# Altium schematic sheet [04] - RP2040.SchDoc
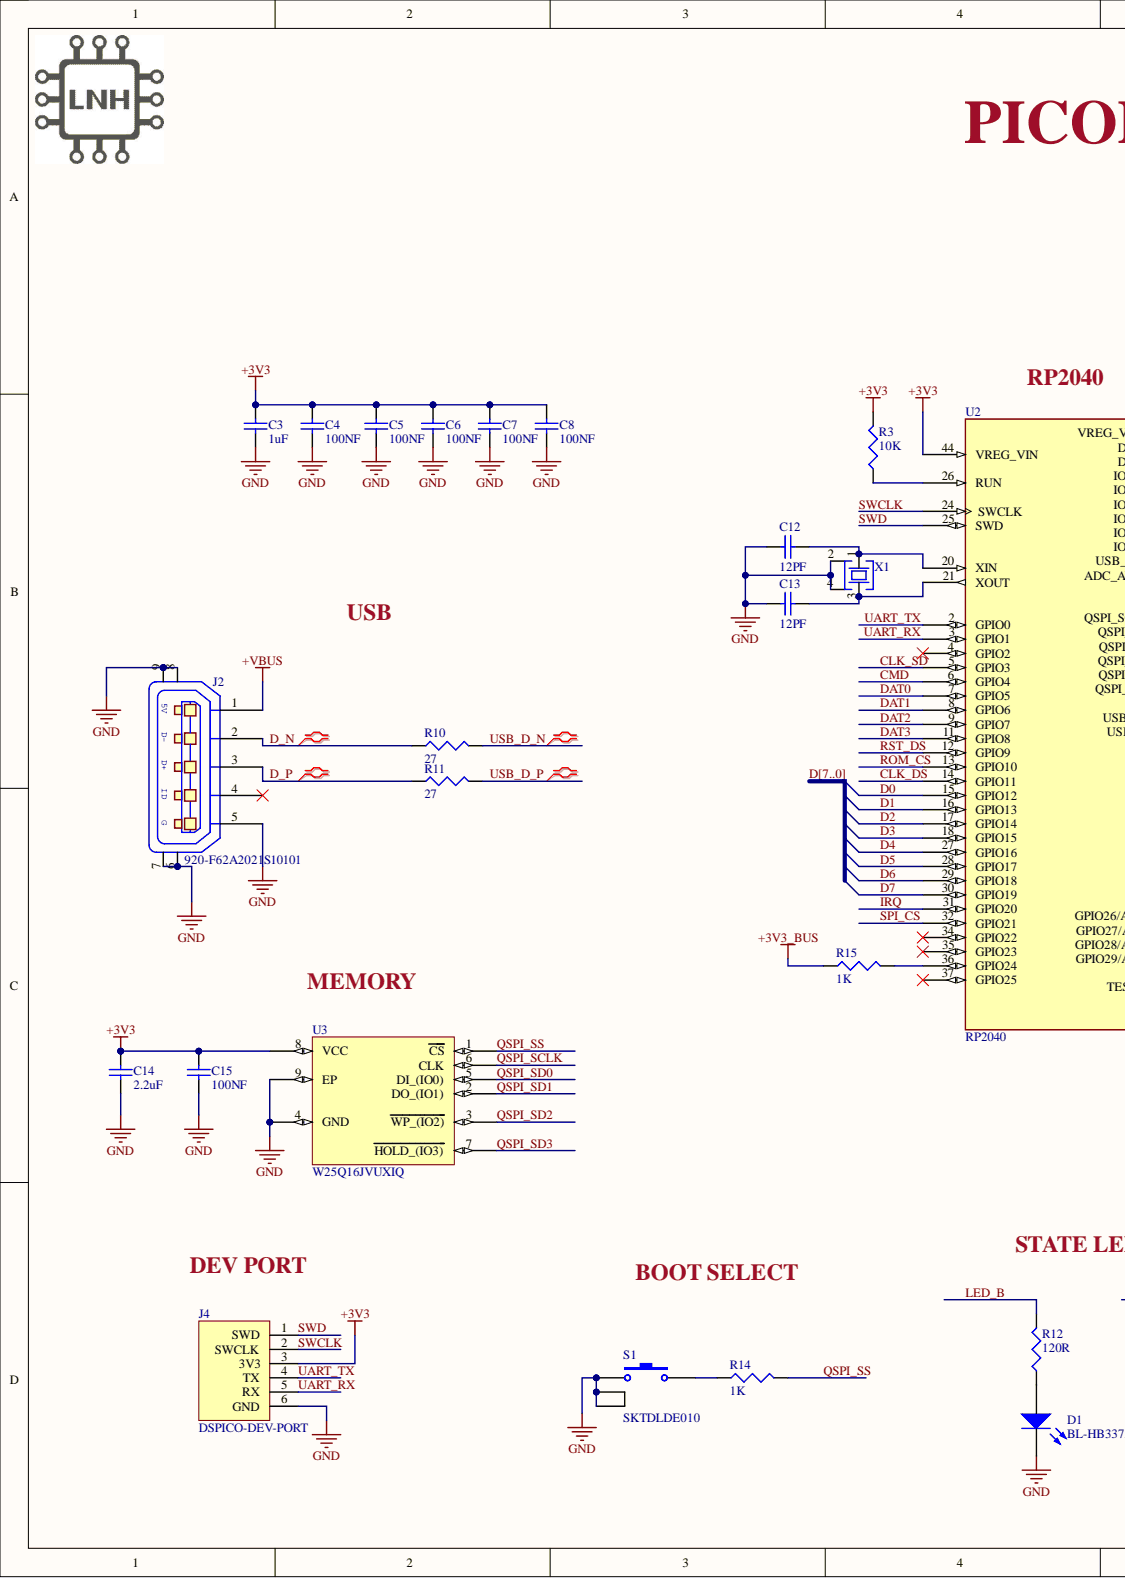
[diagram p0: [04] - RP2040.SchDoc, left]
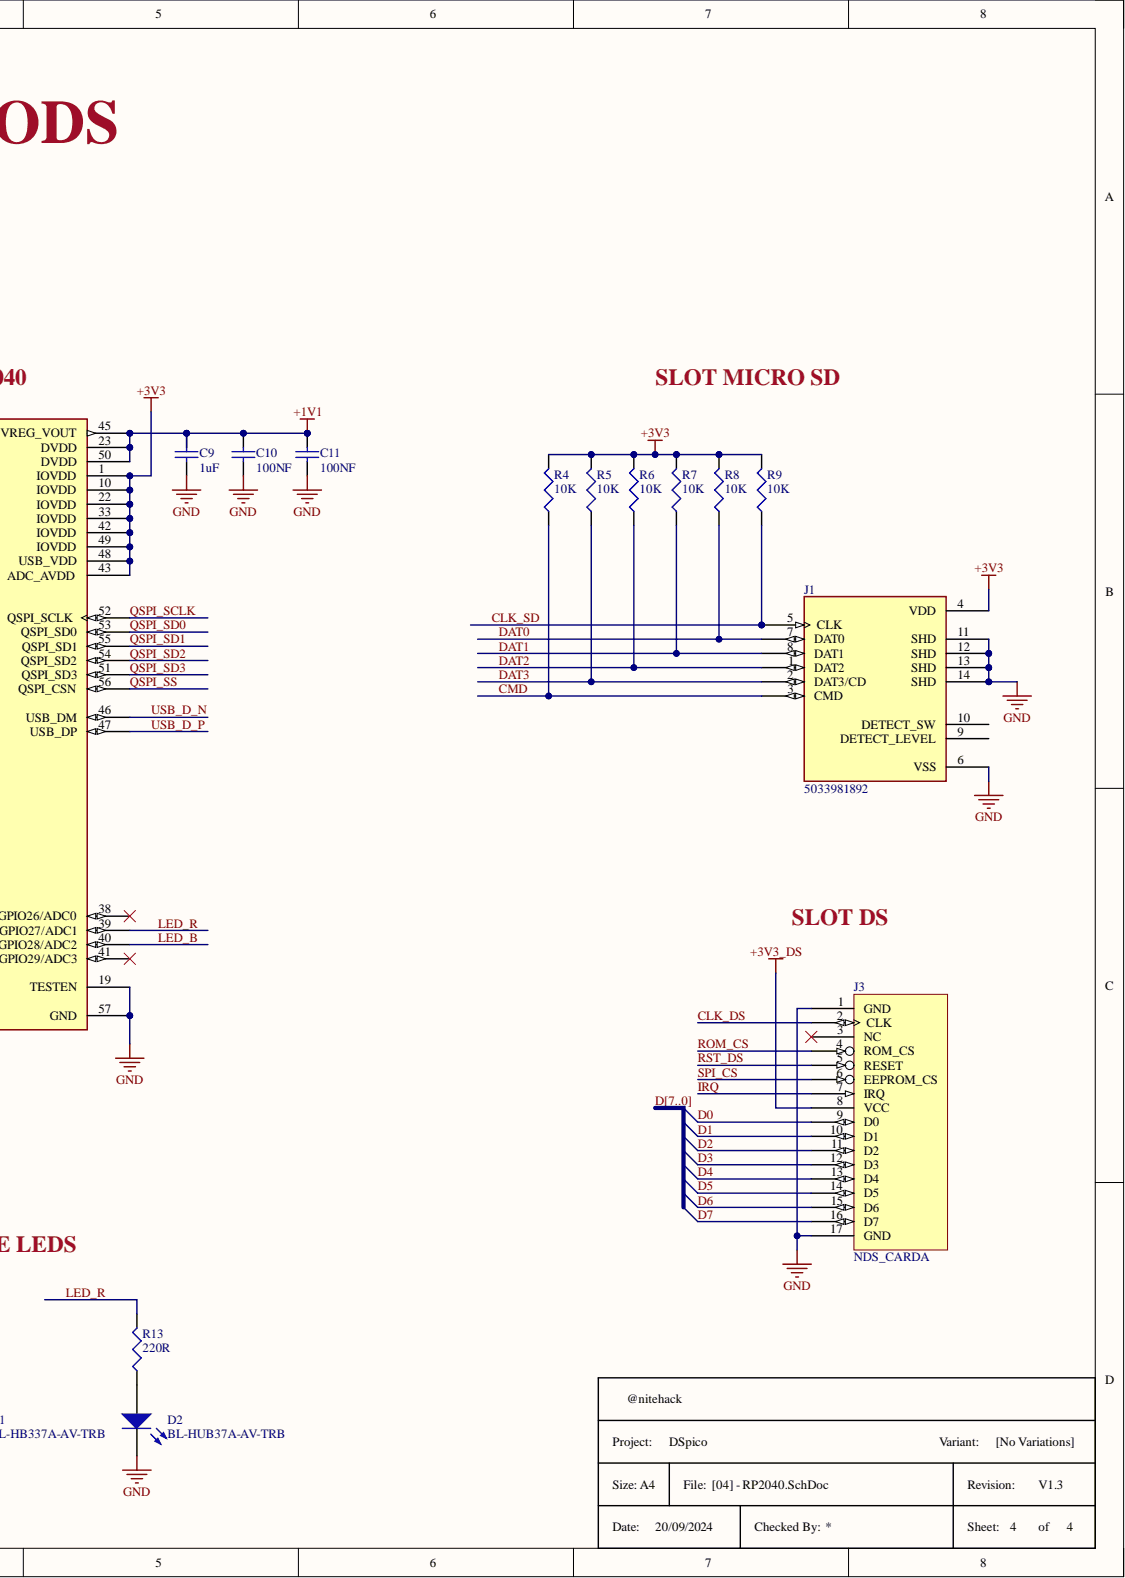
[diagram p1: [04] - RP2040.SchDoc, right]

## Parts
C3  1uF  [C0402]
C4  100NF  [C0402]
C5  100NF  [C0402]
C6  100NF  [C0402]
C7  100NF  [C0402]
C8  100NF  [C0402]
C9  1uF  [C0402]
C10  100NF  [C0402]
C11  100NF  [C0402]
C12  12PF  [C0402]
C13  12PF  [C0402]
C14  2.2uF  [C0402]
C15  100NF  [C0402]
D1  BL-HB337A-AV-TRB  [LED0402-RD]
D2  BL-HUB37A-AV-TRB  [LED0402-RD]
J1  5033981892  [503398-1892_MOL]
J2  920-F62A2021S10101  [MICRO-USB_MICRO-USB-31]
J3  NDS_CARDA  [NDS_CARD]  (no BOM)
J4  DSPICO-DEV-PORT  [EP_DEV_PORT]  (no BOM)
R3  10K  [R0402]
R4  10K  [R0402]
R5  10K  [R0402]
R6  10K  [R0402]
R7  10K  [R0402]
R8  10K  [R0402]
R9  10K  [R0402]
R10  value 27  [R0402]  (hidden comment: RC0402FR-0727RL)
R11  value 27  [R0402]  (hidden comment: RC0402FR-0727RL)
R12  120R  [R0402]
R13  220R  [R0402]
R14  1K  [R0402]
R15  1K  [R0402]
S1  SKTDLDE010  [KEY-SMD_SKTDLDE010]
U2  RP2040  [QFN40P700X700X90-57N]
U3  W25Q16JVUXIQ  [SON50P300X200X60-9N]
X1  XYDBPCNANF-12MHZ  [OSC-SMD_4P-L2.5-W2.0-BL]  (value not drawn)

## Nets
+1V1: C9.2 C10.2 C11.2 U2.23(DVDD) U2.45(VREG_VOUT) U2.50(DVDD)
+3V3: C3.2 C4.2 C5.2 C6.2 C7.2 C8.2 C14.2 C15.2 J1.4(VDD) J4.3(3V3) R3.2 R4.2 R5.2 R6.2 R7.2 R8.2 R9.2 U2.1(IOVDD) U2.10(IOVDD) U2.22(IOVDD) U2.33(IOVDD) U2.42(IOVDD) U2.43(ADC_AVDD) U2.44(VREG_VIN) U2.48(USB_VDD) U2.49(IOVDD) U3.8(VCC)
+3V3_BUS: R15.1
+3V3_DS: J3.8(VCC)
+VBUS: J2.1
GND: C3.1 C4.1 C5.1 C6.1 C7.1 C8.1 C9.1 C10.1 C11.1 C12.1 C13.1 C14.1 C15.1 D1.1 D2.1 J1.6(VSS) J1.11(SHD) J1.12(SHD) J1.13(SHD) J1.14(SHD) J2.5 J2.6 J2.7 J2.8 J2.9 J3.1(GND) J3.17(GND) J4.6(GND) S1.1 S1.3 S1.4 U2.19(TESTEN) U2.57(GND) U3.4(GND) U3.9(EP) X1.2 X1.4
CLK_DS: J3.2(CLK) U2.14(GPIO11)
CLK_SD: J1.5(CLK) R9.1 U2.5(GPIO3)
CMD: J1.3(CMD) R4.1 U2.6(GPIO4)
D0: J3.9(D0) U2.15(GPIO12)  [also labelled D]
D1: J3.10(D1) U2.16(GPIO13)  [also labelled D]
D2: J3.11(D2) U2.17(GPIO14)  [also labelled D]
D3: J3.12(D3) U2.18(GPIO15)  [also labelled D]
D4: J3.13(D4) U2.27(GPIO16)  [also labelled D]
D5: J3.14(D5) U2.28(GPIO17)  [also labelled D]
D6: J3.15(D6) U2.29(GPIO18)  [also labelled D]
D7: J3.16(D7) U2.30(GPIO19)  [also labelled D]
D_N: J2.2 R10.1
D_P: J2.3 R11.1
DAT0: J1.7(DAT0) R8.1 U2.7(GPIO5)
DAT1: J1.8(DAT1) R7.1 U2.8(GPIO6)
DAT2: J1.1(DAT2) R6.1 U2.9(GPIO7)
DAT3: J1.2(DAT3/CD) R5.1 U2.11(GPIO8)
IRQ: J3.7(IRQ) U2.31(GPIO20)
LED_B: R12.2 U2.40(GPIO28/ADC2)
LED_R: R13.2 U2.39(GPIO27/ADC1)
QSPI_SCLK: U2.52(QSPI_SCLK) U3.6(CLK)
QSPI_SD0: U2.53(QSPI_SD0) U3.5(DI_(IO0))
QSPI_SD1: U2.55(QSPI_SD1) U3.2(DO_(IO1))
QSPI_SD2: U2.54(QSPI_SD2) U3.3(WP_(IO2))
QSPI_SD3: U2.51(QSPI_SD3) U3.7(HOLD_(IO3))
QSPI_SS: R14.2 U2.56(QSPI_CSN) U3.1(CS)
ROM_CS: J3.4(ROM_CS) U2.13(GPIO10)
RST_DS: J3.5(RESET) U2.12(GPIO9)
SPI_CS: J3.6(EEPROM_CS) U2.32(GPIO21)
SWCLK: J4.2(SWCLK) U2.24(SWCLK)
SWD: J4.1(SWD) U2.25(SWD)
UART_RX: J4.5(RX) U2.3(GPIO1)
UART_TX: J4.4(TX) U2.2(GPIO0)
USB_D_N: R10.2 U2.46(USB_DM)
USB_D_P: R11.2 U2.47(USB_DP)
NetC12_2: C12.2 U2.20(XIN) X1.1
NetC13_2: C13.2 U2.21(XOUT) X1.3
NetD1_2: D1.2 R12.1
NetD2_2: D2.2 R13.1
NetR3_1: R3.1 U2.26(RUN)
NetR14_1: R14.1 S1.2
NetR15_2: R15.2 U2.36(GPIO24)

Not connected: J1 9(DETECT_LEVEL) 10(DETECT_SW); J2 4; J3 3(NC); U2 4(GPIO2) 34(GPIO22) 35(GPIO23) 37(GPIO25) 38(GPIO26/ADC0) 41(GPIO29/ADC3)

## Library data of the parts
C3 C9 = C1005X5R1E105K050BC: TDK - C1005X5R1E105K050BC - COND, CERÁ MULT, X5R, 1UF, 25V, 0402; Dielectric Material: Ceramic; Tolerance: 10%; Capacitance: 1uF; Case Code (Imperial): 0402; Case Code (Metric): 1005; Case/Package: 0402; Component Kind: Standard; ComponentLink1Description: http://www.farnell.com/datasheets/1796654.pdf; ComponentLink1URL: http://www.farnell.com/datasheets/1796654.pdf; Composition: Ceramic; Depth: 0.5mm; Dielectric: X5R; Height: 0.5mm; Lead Free: Lead Free; Length: 1mm; Library Name: SCHLIB.SchLib; Manufacturer: TDK; Manufacturer Part Number: C1005X5R1E105K050BC; Max Operating Temperature: 85°C; Min Operating Temperature: -55°C; Mount: Surface Mount; Package Quantity: 1; Packaging: Tape and Reel; Pricing: 10=0,0948, 100=0,0638, 500=0,0468, 2500=0,0336, 5000=0,028, 10000=0,021, 50000=0,0175, 100000=0,0153 (EUR); Radiation Hardening: No; REACH SVHC: No SVHC; RoHS: YES; Stock: 131328; Storage Location: C1-F2; Supplier 1: Farnell; Supplier 2: RSComponents; Supplier 3: Mouser; Supplier 4: Digi-Key; Supplier Part Number 1: 2346884; Supplier Part Number 2: 1110310; Supplier Part Number 3: 810-C1005X5R1E105K; Supplier Part Number 4: 445-9066-1-ND; Termination: SMD/SMT; Thickness: 0.022inch; Voltage Rating: 25V; Voltage Rating (DC): 25V; Weight: 2.3E-05oz; Width: 0.02inch
C4-C8 C10 C11 C15 = GRM155R71C104KA88D: 0402 0.1 uF 16V ±10 % Tolerance X7R SMT Multilayer Ceramic Capacitor; Manufacturer: Murata; Insulation Resistance: 10GR; Tolerance: 10%; Capacitance: 100nF; Case Code (Imperial): 0402; Case Code (Metric): 1005; Case/Package: 0402; Category: Ceramic Capacitors; Component Kind: Standard; ComponentLink1Description: https://datasheet.ciiva.com/129/grm155r71c104ka88-01-129739.pdf?src-supplier=Digi-Key; ComponentLink1URL: https://datasheet.ciiva.com/129/grm155r71c104ka88-01-129739.pdf?src-supplier=Digi-Key; ComponentLink2Description: https://datasheet.ciiva.com/129/grm155r71c104ka88-01-129740.pdf?src-supplier=Digi-Key; ComponentLink2URL: https://datasheet.ciiva.com/129/grm155r71c104ka88-01-129740.pdf?src-supplier=Digi-Key; ComponentLink3Description: https://datasheet.ciiva.com/18102/getdatasheetpartid-85307-18102905.pdf?src-supplier=Verical; ComponentLink3URL: https://datasheet.ciiva.com/18102/getdatasheetpartid-85307-18102905.pdf?src-supplier=Verical; ComponentLink4Description: https://datasheet.ciiva.com/29199/454098-da-01-en-vs-kondensator-0402-29199799.pdf?src-supplier=Conrad; ComponentLink4URL: https://datasheet.ciiva.com/29199/454098-da-01-en-vs-kondensator-0402-29199799.pdf?src-supplier=Conrad; ComponentLink5Description: https://datasheet.ciiva.com/7937/4360-7937615.pdf?src-supplier=Element14; ComponentLink5URL: https://datasheet.ciiva.com/7937/4360-7937615.pdf?src-supplier=Element14; ComponentLink6Description: https://datasheet.ciiva.com/14116/grmseries-highdielectrictype-eng-14116878.pdf?src-supplier=Distrelec; ComponentLink6URL: https://datasheet.ciiva.com/14116/grmseries-highdielectrictype-eng-14116878.pdf?src-supplier=Distrelec; ComponentLink7Description: https://datasheet.ciiva.com/14103/grmseries-highdielectrictype-eng-14103750.pdf?src-supplier=Distrelec; ComponentLink7URL: https://datasheet.ciiva.com/14103/grmseries-highdielectrictype-eng-14103750.pdf?src-supplier=Distrelec; ComponentLink8Description: https://datasheet.ciiva.com/28534/4360-28534127.pdf?src-supplier=Element14; ComponentLink8URL: https://datasheet.ciiva.com/28534/4360-28534127.pdf?src-supplier=Element14; ComponentLink9Description: https://datasheet.ciiva.com/28534/4360-28534133.pdf?src-supplier=Element14; ComponentLink9URL: https://datasheet.ciiva.com/28534/4360-28534133.pdf?src-supplier=Element14; ComponentLink10Description: https://datasheet.ciiva.com/16199/product-16199000.pdf?src-supplier=Future+Electronics; ComponentLink10URL: https://datasheet.ciiva.com/16199/product-16199000.pdf?src-supplier=Future+Electronics; Depth: 0.5mm; Dielectric: X7R; Dielectric Material: Ceramic; Height: 0.5mm; Lead Free: Lead Free; Length: 1mm; Library Name: SCHLIB.SchLib; Manufacturer Part Number: GRM155R71C104KA88D; Material: Ceramic; Max Operating Temperature: 125°C; Min Operating Temperature: -55°C; Mount: Surface Mount; Number of Pins: 2; Package Quantity: 1; Packaging: Tape and Reel; Pricing 1: 1=0,10000, 10=0,02200, 50=0,01180, 100=0,00960, 500=0,00688, 1000=0,00541, 2500=0,00492, 5000=0,00452 (USD); Radiation Hardening: No; REACH SVHC: No SVHC; RoHS: Yes; RoHS Compliant: Yes; Stock 1: 30795639; Supplier 1: Digi-Key; Supplier Part Number 1: 490-3261-1-ND; Termination: SMD/SMT; Thickness: 0.022inch; Voltage: 16V; Voltage Rating: 16V; Voltage Rating (DC): 16V; Width: 0.5mm
C12 C13 = 04025A120JAT2A: CAP CER 12PF 50V C0G/NP0 0402; Manufacturer: Kyocera AVX; Dielectric: C0G; Insulation Resistance: 100GR; Temperature Coefficient: 30ppm/°C; Tolerance: 5%; Capacitance: 12pF; Case Code (Imperial): 0402; Case Code (Metric): 1005; Case/Package: 0402; Category: Ceramic Capacitors; Component Kind: Standard; Construction: Flat; Depth: 0.5mm; Height: 0.5mm; Lead Free: Lead Free; Length: 1mm; Library Name: SCHLIB.SchLib; Manufacturer Part Number: 04025A120JAT2A; Max Operating Temperature: 125°C; Min Operating Temperature: -55°C; Mount: Surface Mount,PCB; Number of Pins: 2; Package Quantity: 1; Packaging: Tape and Reel; Radiation Hardening: No; Reach SVHC Compliant: Yes; RoHS: Yes; RoHS Compliant: Yes; Series: -; Supplier 1: Newark; Supplier Part Number 1: 96M1132; Termination: SMD/SMT; Thickness: 0.022inch; Voltage: 50V; Voltage Rating: 50V; Voltage Rating (DC): 50V; Width: 0.02inch
C14 = C1005X5R1A225K050BC: TDK - C1005X5R1A225K050BC - COND, CERÁ MULT, X5R, 2.2UF, 10V, 0402; .PCBCheck: VMM; Component Kind: Standard; ComponentLink1Description: http://bcove.me/dwwzmldz; ComponentLink1URL: http://bcove.me/dwwzmldz; ComponentLink2Description: http://www.farnell.com/datasheets/1913358.pdf; ComponentLink2URL: http://www.farnell.com/datasheets/1913358.pdf; Library Name: SCHLIB.SchLib; Manufacturer: TDK; Manufacturer Part Number: C1005X5R1A225K050BC; Pricing 1: 5=0.142, 300=0.0926, 1000=0.0836, 2500=0.0667, 5000=0.0564 (EUR); RoHS: YES; Stock 1: 13179; Supplier 1: Farnell; Supplier 2: Mouser; Supplier 3: Digi-Key; Supplier Part Number 1: 2346879; Supplier Part Number 2: 810-C1005X5R1A225K; Supplier Part Number 3: 445-7392-1-ND; .SCHCheck: VMM
D1 = BL-HB337A-AV-TRB: Blue 0402 1X.5X4MM; Manufacturer: American Bright; Dominant Wavelength: 571nm; Forward Voltage: 2.8V; Lens Color: Colorless; Lens Size: 0.5mm; Lens Style: Flat; Lens Transparency: Clear; Luminous Intensity: 35mcd; Test Current: 20mA; Viewing Angle: 120°; Case Code (Imperial): 0402; Case Code (Metric): 1005; Case/Package: 0402; Category: LEDs; Color: Blue; Component Kind: Standard; ComponentLink1Description: http://www.americanbrightled.com/pdffiles/smt/BL-HGE37A-AV-TRB.pdf?src-supplier=Digi-Key; ComponentLink1URL: http://www.americanbrightled.com/pdffiles/smt/BL-HGE37A-AV-TRB.pdf?src-supplier=Digi-Key; Height: 0.45mm; Length: 1mm; Library Name: SCHLIB.SchLib; Manufacturer Part Number: BL-HB337A-AV-TRB; Mount: Surface Mount; Packaging: Cut Tape; Pricing 1: 1=0,35000, 10=0,27300, 100=0,12260, 500=0,11074, 1000=0,09097 (USD); RoHS: Yes; RoHS Compliant: Yes; Stock 1: 2065; Width: 0.5mm
D2 = BL-HUB37A-AV-TRB: Red 0402 1X.5X4MM; Manufacturer: American Bright; Dominant Wavelength: 571nm; Forward Voltage: 2V; Lens Color: Colorless; Lens Size: 0.5mm; Lens Style: Flat; Lens Transparency: Clear; Luminous Intensity: 35mcd; Test Current: 20mA; Viewing Angle: 120°; Case Code (Imperial): 0402; Case Code (Metric): 1005; Case/Package: 0402; Category: LEDs; Color: Red; Component Kind: Standard; ComponentLink1Description: http://www.americanbrightled.com/pdffiles/smt/BL-HGE37A-AV-TRB.pdf?src-supplier=Digi-Key; ComponentLink1URL: http://www.americanbrightled.com/pdffiles/smt/BL-HGE37A-AV-TRB.pdf?src-supplier=Digi-Key; Height: 0.45mm; Length: 1mm; Library Name: SCHLIB.SchLib; Manufacturer Part Number: BL-HUB37A-AV-TRB; Mount: Surface Mount; Packaging: Cut Tape; Pricing 1: 1=0,35000, 10=0,27300, 100=0,12260, 500=0,11074, 1000=0,09097 (USD); RoHS: Yes; RoHS Compliant: Yes; Stock 1: 2065; Width: 0.5mm
J3 = NDS_CARDA: DeviceName: A; SymbolName_1: NDS_CONNECTOR; DeviceSetName: NDS_CARD; GateName_1: NDS_CARD; Library Name: SCHLIB.SchLib; LibraryName: nds
J4 = PICO-DEVELOP-PORT: DSpico Development port; Manufacturer: LNH; Connector Type: Outer Shroud Contact; Gender: Male; Number of Contacts: 6; Pitch: 2.54; Voltage Rating: 3.3V; Wire/Cable Gauge: 26AWG; ComponentLink1Description: https://datasheet.ciiva.com/25617/0900766b810cef18-25617270.pdf; ComponentLink1URL: https://datasheet.ciiva.com/25617/0900766b810cef18-25617270.pdf; ComponentLink2Description: https://datasheet.ciiva.com/16379/jstsm03b-ghs-tb-lf-sn-16379590.pdf; ComponentLink2URL: https://datasheet.ciiva.com/16379/jstsm03b-ghs-tb-lf-sn-16379590.pdf; ComponentLink3Description: https://datasheet.ciiva.com/8052/5438-8052261.pdf; ComponentLink3URL: https://datasheet.ciiva.com/8052/5438-8052261.pdf; Current Rating: ?; Fastening Type: Ramp; Library Name: SCHLIB.SchLib; Manufacturer Part Number: PICO-DEVELOP-PORT; Max Operating Temperature: 85°C; Min Operating Temperature: -25°C; Mount: Surface Mount; Number of Positions: 6; Number of Rows: 1; Supplier 1: Farnell; Termination: Solder
R3-R9 = CRCW040210K0FKED: VISHAY DRALORIC - CRCW040210K0FKED - RESISTENCIA, 10K, 0.063W, 1%, 0402, SMD; Max Power Dissipation: 63mW; Resistance: 10kR; Temperature Coefficient: 100ppm/°C; Voltage Rating: 50V; Case Code (Imperial): 0402; Case Code (Metric): 1005; Case/Package: 0402; Component Kind: Standard; ComponentLink1Description: http://www.farnell.com/datasheets/1720486.pdf; ComponentLink1URL: http://www.farnell.com/datasheets/1720486.pdf; ComponentLink2Description: http://www.farnell.com/cad/1551228.zip; ComponentLink2URL: http://www.farnell.com/cad/1551228.zip; Composition: Thick Film; Contact Plating: Tin; Depth: 0.5mm; Features: Automotive AEC-Q200; Height: 0.35mm; Lead Free: Lead Free; Length: 1mm; Library Name: SCHLIB.SchLib; Manufacturer: Vishay; Manufacturer Part Number: CRCW040210K0FKED; Max Operating Temperature: 155°C; Military Standard: Not; Min Operating Temperature: -55°C; Mount: Surface Mount; Number of Pins: 2; Number of Terminations: 2; Package Quantity: 10; Packaging: Tape and Reel; Power Rating: 63mW; Pricing: 10=0.0147, 100=0.0113, 500=0.0091, 2500=0.007, 5000=0.0055 (GBP); Radiation Hardening: No; REACH SVHC: Unknown; RoHS: Y-EX; Stock: 0; Storage Location: C1-F2; Supplier 1: Farnell; Supplier 2: RSComponents; Supplier 3: Mouser; Supplier 4: Digi-Key; Supplier Part Number 1: 1469669; Supplier Part Number 2: 6784697; Supplier Part Number 3: 71-CRCW0402-10K-E3; Supplier Part Number 4: 541-10.0KLTR-ND; Termination: SMD/SMT; Tolerance: 1%; Voltage Rating (DC): 50V; Weight: 2.3E-05oz; Width: 0.02inch
R10 R11 = RC0402FR-0727RL: RES 27 OHM 1% 1/16W 0402; Additional Feature: LASER TRIMMABLE; Package Height: 0.35mm; Package Length: 1mm; Package Width: 0.5mm; Rated Power Dissipation (P): 62.5mW; Rated Temperature: 70°C; Resistance: 27R; Temperature Coefficient: 100ppm/°C; Working Voltage: 50V; Case/Package: 0402; Component Kind: Standard; Construction: Chip; JESD-609 Code: e3; Library Name: SCHLIB.SchLib; Max Operating Temperature: 155°C; Min Operating Temperature: -55°C; Mount: Surface Mount; Number of Pins: 2; Package Shape: Rectangular; Package Style: SMTMeter; Packaging: Tape and Reel; REACH SVHC: Yes; Resistor Type: FIXED RESISTOR; RoHS Compliant: Yes; Size Code: 0402; Technology: METAL GLAZE/THICK FILM; Terminal Finish: Nickel; Termination: Wraparound; Tolerance: 1%
R12 = RC0402FR-07120RL: Res General Purpose Thick Film 0402 120 Ohm 1% 1/16W ±100ppm/°C Molded Paper T/R; Manufacturer: Yageo; Max Power Dissipation: 63mW; Power Rating: 62.5mW; Voltage Rating: 50V; Case Code (Imperial): 0402; Case Code (Metric): 1005; Case/Package: 0402; Category: Chip SMD Resistors; Component Kind: Standard; ComponentLink1Description: https://datasheet.ciiva.com/12667/pyu-rc0402-51-rohs-l-6-r-12667958.pdf?src-supplier=Mouser; ComponentLink1URL: https://datasheet.ciiva.com/12667/pyu-rc0402-51-rohs-l-6-r-12667958.pdf?src-supplier=Mouser; ComponentLink2Description: https://datasheet.ciiva.com/7849/1018594-7849831.pdf?src-supplier=Element14; ComponentLink2URL: https://datasheet.ciiva.com/7849/1018594-7849831.pdf?src-supplier=Element14; Construction: Rectangular; Depth: 0.5mm; Features: Moisture Resistant; Height: 0.016inch; Lead Free: 5697LeadFree; Length: 0.039inch; Library Name: SCHLIB.SchLib; Manufacturer Part Number: RC0402FR-07120RL; Max Operating Temperature: 155°C; Military Standard: Not; Min Operating Temperature: -55°C; Number of Terminations: 2; Package Quantity: 1; Packaging: Tape and Reel; Pricing 1: 10=0,0101, 100=0,0056, 500=0,0044, 2500=0,0032, 5000=0,0026, 10000=0,002, 50000=0,0019, 100000=0,0018 (EUR); Reach SVHC Compliant: No; Resistance: 120R; Resistance Tolerance: 1%; Resistor Type: Thick Film; RoHS: Yes; RoHS Compliant: Yes; Series: RC; Size Code: 0402; Stock 1: 238785; Supplier 1: Farnell; Supplier Part Number 1: 9239120; Technology: THICK FILM; Temperature Coefficient: 100ppm/°C; Termination: SMD/SMT; Tolerance: 1%; Value: 120R; Voltage Rating (AC): 50V; Width: 0.02inch
R13 = RC0402FR-07220RL: SMD Chip Resistor, 220 Ohm, ± 1%, 62.5 mW, 0402 [1005 Metric], Thick Film, General Purpose; Manufacturer: Yageo; Max Power Dissipation: 63mW; Power Rating: 62.5mW; Temperature Coefficient: 100ppm/°C; Voltage Rating: 50V; Case Code (Imperial): 0402; Case Code (Metric): 1005; Case/Package: 0402; Category: Chip SMD Resistors; Component Kind: Standard; ComponentLink1Description: http://www.farnell.com/datasheets/2167052.pdf?src-supplier=Farnell; ComponentLink1URL: http://www.farnell.com/datasheets/2167052.pdf?src-supplier=Farnell; ComponentLink2Description: http://www.farnell.com/datasheets/1018594.pdf?src-supplier=Farnell; ComponentLink2URL: http://www.farnell.com/datasheets/1018594.pdf?src-supplier=Farnell; ComponentLink3Description: http://www.farnell.com/datasheets/1686128.pdf?src-supplier=Element14; ComponentLink3URL: http://www.farnell.com/datasheets/1686128.pdf?src-supplier=Element14; ComponentLink4Description: http://www.farnell.com/datasheets/2167052.pdf?src-supplier=Newark; ComponentLink4URL: http://www.farnell.com/datasheets/2167052.pdf?src-supplier=Newark; ComponentLink5Description: http://www.farnell.com/datasheets/2167052.pdf?src-supplier=Element14; ComponentLink5URL: http://www.farnell.com/datasheets/2167052.pdf?src-supplier=Element14; ComponentLink6Description: https://datasheet.octopart.com/RC0402FR-07220RL-Yageo-datasheet-10408619.pdf?src-supplier=Element14; ComponentLink6URL: https://datasheet.octopart.com/RC0402FR-07220RL-Yageo-datasheet-10408619.pdf?src-supplier=Element14; Construction: Rectangular; Depth: 0.5mm; Features: Moisture Resistant; Height: 0.016inch; Lead Free: 5697LeadFree; Length: 0.039inch; Library Name: SCHLIB.SchLib; Manufacturer Part Number: RC0402FR-07220RL; Max Operating Temperature: 155°C; Military Standard: Not; Min Operating Temperature: -55°C; Number of Pins: 2; Number of Terminations: 2; Package Quantity: 1; Packaging: Tape and Reel; Pricing 1: 10=0,0099, 100=0,0055, 500=0,0044, 2500=0,0032, 5000=0,0031 (EUR); Reach SVHC Compliant: No; Resistance: 220R; Resistance Tolerance: 1%; Resistor Type: Thick Film; RoHS: Yes; RoHS Compliant: Yes; Series: RC; Size Code: 0402; Stock 1: 412549; Supplier 1: Farnell; Supplier Part Number 1: 9239154; Technology: THICK FILM; Termination: SMD/SMT; Tolerance: 1%; Value: 220R; Voltage Rating (AC): 50V; Voltage Rating (DC): 50V; Width: 0.02inch
R14 R15 = CRCW04021K00FKEDC: VISHAY - CRCW04021K00FKED - RES, PELÍC GRUESA, 1K, 1%, 0.063W, 0402; Coeficiente de Temperatura: ± 100; Encapsulado: Cinta Cortada; Estándar de Certificación para Automoción: AEC-Q200; Nivel de Sensibilidad a la Humedad (MSL): MSL 1 - Ilimitado; Núm. de Contactos Macho: 2; Potencia Nominal: 63; Rango de Producto: Serie AEC-Q200 CRCW; Rango de Temperatura de Funcionamiento: -55°C a +155; Resistencia: 1; Resistencia, Montaje: Dispositivo de Montaje Superficial (SMD); Temperatura de Trabajo Máx.: 155; Temperatura de Trabajo Mín.: -55°C<!--FANDB--><div class="features-and-benefits-container"><div class="features-and-benefits-description"><span class="features-benefits-value">The Vishay D/CRCW e3 series are standard thick film chip resistors. The resistance range includes E96 and E24 decade values from 1ohm to 10Mohm. CRCW e3 series resistors have a pure tin solder contacts on the Ni barrier layer that provides compatibility with reflow soldering process.</span></div><div class="features-and-benefits-list"><ul class="features-and-benefits-list-list"><li class="features-and-benefits-list-item">Automotive grade AEC-Q200 qualified</li><li class="features-and-benefits-list-item">EIA 0402, 0603, 0805, 1206, 1210, 1218, 2010, 2512 standard case sizes available</li><li class="features-and-benefits-list-item">Stability &Delta; Tensión Nominal: 50; Tipo de Elemento Resistivo: Película Gruesa; Tipo de Resistencia: 0402 [Métrica 1005]; Component Kind: Standard; ComponentLink1Description: http://www.farnell.com/datasheets/2111203.pdf; ComponentLink1URL: http://www.farnell.com/datasheets/2111203.pdf; ComponentLink2Description: http://www.farnell.com/cad/1551424.zip; ComponentLink2URL: http://www.farnell.com/cad/1551424.zip; Library Name: SCHLIB.SchLib; Manufacturer: VISHAY; Manufacturer Part Number: CRCW04021K00FKED; Pricing 1: 10=0,0125, 400=0,0097, 1000=0,0069, 2500=0,0057, 5000=0,0041 (EUR); RoHS: Y-EX; Stock 1: 83181; Supplier 1: Farnell; Supplier 2: Digi-Key; Supplier Part Number 1: 1469662; Supplier Part Number 2: 541-1.00KLCT-ND; Tolerancia de Resistencia: ± 1%
S1 = SKTDLDE010: Switch Tactile N.O. SPST Rectangular Button Gull Wing 0.05A 12VDC 1.6N SMD T/R; Manufacturer: Alps Electric; Category: Tactile Switches; Component Kind: Standard; ComponentLink1Description: http://www.alps.com/products/WebObjects/catalog.woa/E/HTML/Tact/SurfaceMount/SKTD/SKTDLDE010.html?src-supplier=Mouser; ComponentLink1URL: http://www.alps.com/products/WebObjects/catalog.woa/E/HTML/Tact/SurfaceMount/SKTD/SKTDLDE010.html?src-supplier=Mouser; ComponentLink2Description: http://datasheet.octopart.com/SKTDLDE010-ALPS-datasheet-154833203.pdf?src-supplier=Rs; ComponentLink2URL: http://datasheet.octopart.com/SKTDLDE010-ALPS-datasheet-154833203.pdf?src-supplier=Rs; Library Name: SCHLIB.SchLib; Manufacturer Part Number: SKTDLDE010; Pricing 1: 10=0,90800, 50=0,83200, 100=0,80800, 250=0,78600, 500=0,76500 (EUR); RoHS: Yes; RoHS Compliant: Yes; Stock 1: 500; Supplier 1: Rs
U2 = RP2040: RP2040 chip for Raspberry Pi Pico - ARM Cortex-M0+ MCU 32-Bit; Availability: In Stock; Check_prices: https://www.snapeda.com/parts/RP2040/Raspberry+Pi/view-part/?ref=eda; Component Kind: Standard; Library Name: SCHLIB.SchLib; MF: Raspberry Pi; MP: RP2040; Package: None; Price: None; Purchase-URL: https://pricing.snapeda.com/search/part/RP2040/?ref=eda; SnapEDA_Link: https://www.snapeda.com/parts/RP2040/Raspberry+Pi/view-part/?ref=snap
U3 = W25Q16JVUXIQ: NOR Flash Serial (SPI, Dual SPI, Quad SPI) 3V/3.3V 16M-bit 2M x 8 6ns 8-Pin USON EP T/R; MF: Winbond; Availability: In Stock; Check_prices: https://www.snapeda.com/parts/W25Q16JVUXIQ/Winbond/view-part/?ref=eda; Component Kind: Standard; Library Name: SCHLIB.SchLib; MP: W25Q16JVUXIQ; Package: UFDFN-8 Winbond; Price: None; Purchase-URL: https://pricing.snapeda.com/search/part/W25Q16JVUXIQ/?ref=eda; SnapEDA_Link: https://www.snapeda.com/parts/W25Q16JVUXIQ/Winbond/view-part/?ref=snap
J1 = 5033981892: Component Kind: Standard; Library Name: SCHLIB.SchLib
J2 = 920-F62A2021S10101: Component Kind: Standard; Library Name: SCHLIB.SchLib
X1 = XYDBPCNANF-12MHZ: Component Kind: Standard; Description: XYDBPCNANF-12MHZ; Library Name: SCHLIB.SchLib
